# Altium schematic sheet Final out 2.SchDoc("Final out 2")
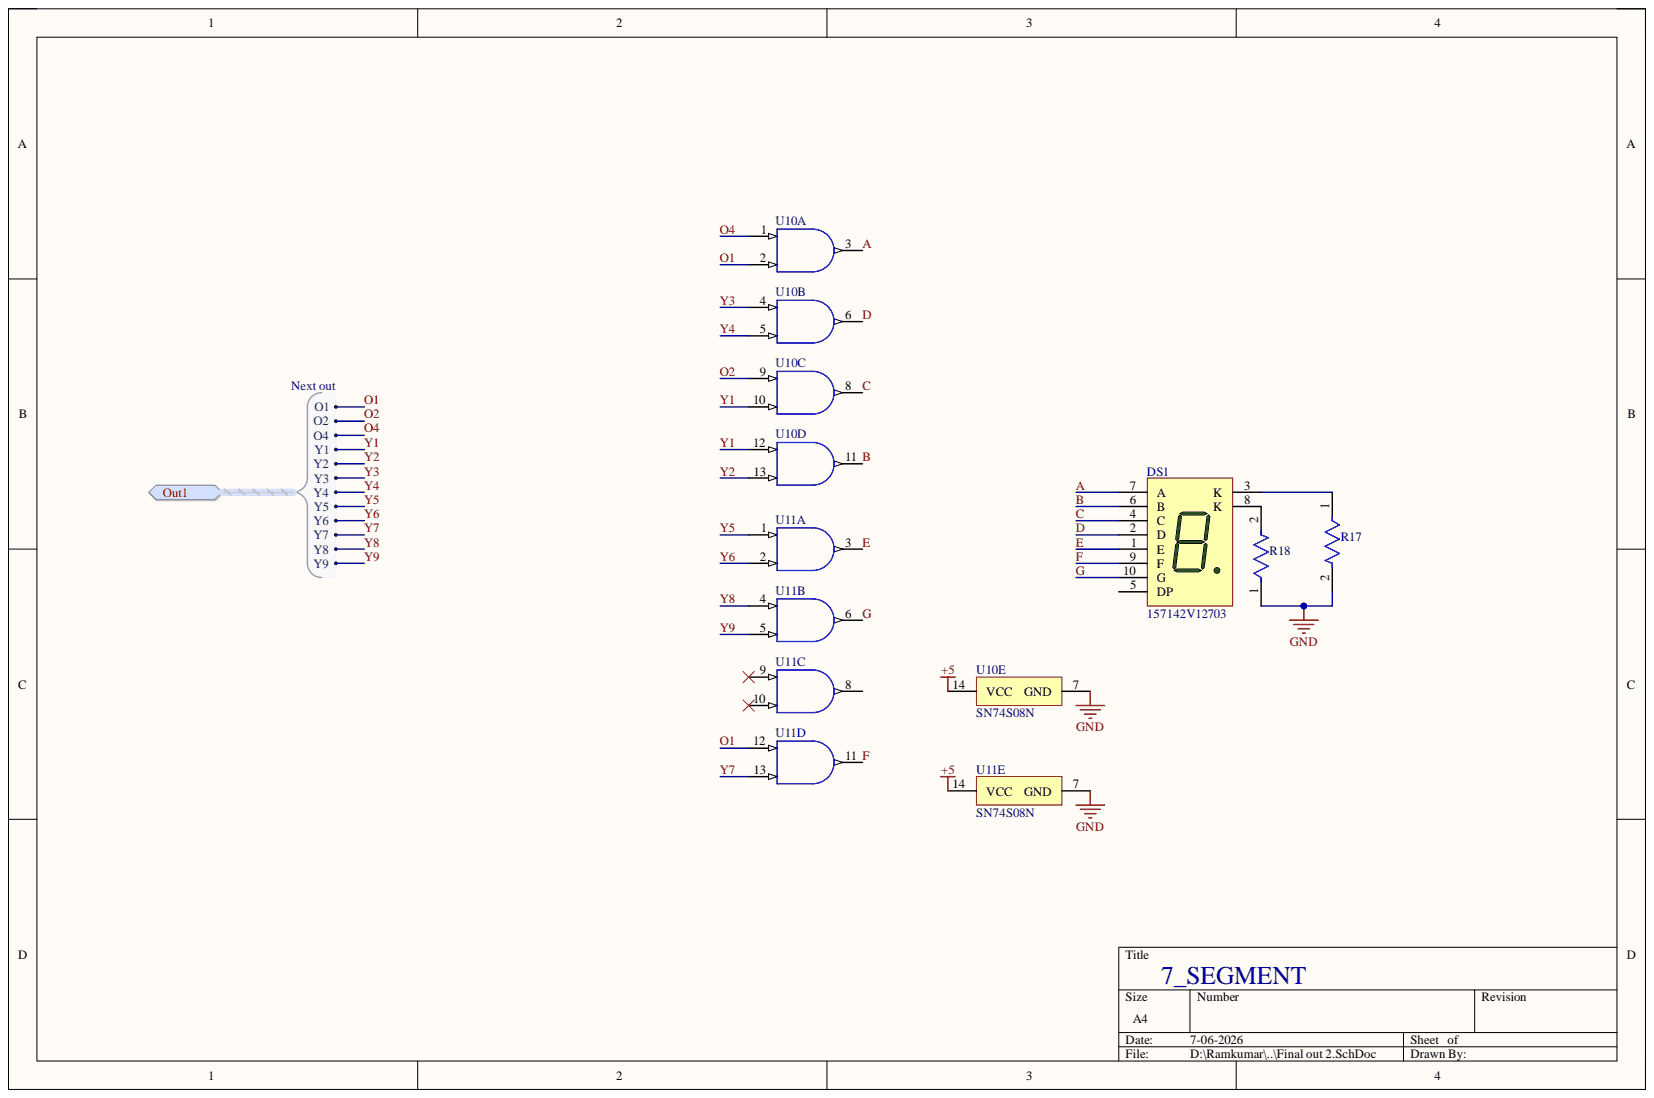
[diagram p0: Final out 2.SchDoc]

## Parts
DS1  157142V12703  [WL-T7DS_L19W12.6H7.9]
R17  MRS25000C1001FCT00  [FP-MRS25-MFG]  (value not drawn)
R18  MRS25000C1001FCT00  [FP-MRS25-MFG]  (value not drawn)
U10  SN74S08N  [N0014A]  (parts A,B,C,D,E)
U11  SN74S08N  [N0014A]  (parts A,B,C,D,E)

## Ports
Out1, Out1.O1, Out1.O2, Out1.O4, Out1.Y1, Out1.Y2, Out1.Y3, Out1.Y4, Out1.Y5, Out1.Y6, Out1.Y7, Out1.Y8, Out1.Y9

## Nets
+5: U10.14(VCC) U11.14(VCC)
GND: R17.2 R18.1 U10.7(GND) U11.7(GND)
A: DS1.7(A) U10.3
B: DS1.6(B) U10.11
C: DS1.4(C) U10.8
D: DS1.2(D) U10.6
E: DS1.1(E) U11.3
F: DS1.9(F) U11.11
G: DS1.10(G) U11.6
O1: U10.2 U11.12 ; port Out1
O2: U10.9 ; port Out1
O4: U10.1 ; port Out1
Y1: U10.10 U10.12 ; port Out1
Y2: U10.13 ; port Out1
Y3: U10.4 ; port Out1
Y4: U10.5 ; port Out1
Y5: U11.1 ; port Out1
Y6: U11.2 ; port Out1
Y7: U11.13 ; port Out1
Y8: U11.4 ; port Out1
Y9: U11.5 ; port Out1
NetDS1_3: DS1.3(K) R17.1
NetDS1_8: DS1.8(K) R18.2

Not connected: DS1 5(DP); U11 8 9 10

## Library data of the parts
DS1 = CMP-03913-119894004-1: 157142V12703 7-Seg Display, Comm Cathode, Grn, 46Mcd Rohs Compliant: Yes; Dominant Wavelength: 572nm; Forward Current: 100mA; Forward Voltage: 2.2V; Luminous Intensity: 25mcd; Max Reverse Voltage (DC): 5V; Component Kind: Standard; Height: 8mm; Length: 19mm; Library Name: Altium Content Vault; Max Operating Temperature: 85°C; Min Operating Temperature: -40°C; NexarPartId: 119894004; RoHS: Compliant; Width: 12.6mm
R17 R18 = CMP-02408-000051-1: RES 1K OHM 0.6W 1% AXIAL; Lead Diameter: 0.6mm; Max Power Dissipation: 600mW; Resistance: 1kR; Temperature Coefficient: 50ppm/°C; Voltage Rating: 350V; Case/Package: Axial; Component Kind: Standard; Construction: Cylindrical; Contact Plating: Tin; Diameter: 2.5mm; Lead Free: Lead Free; Length: 6.5mm; Library Name: Altium Content Vault; Max Operating Temperature: 155°C; Military Standard: Not; Min Operating Temperature: -55°C; Mount: Through Hole; Number of Pins: 2; Number of Terminations: 2; Package Quantity: 5000; Packaging: Tape and Reel; Power Rating: 600mW; Radiation Hardening: No; Resistance Tolerance: 1%; Resistor Type: Metal Film; RoHS Compliant: Yes; Series: MRS25; Technology: THIN FILM; Termination: Axial; Tolerance: 1%; Voltage Rating (DC): 350V; Weight: 0.00776oz; Width: 2.5mm
U10 U11 = CMP-0777-00282-3: Quad 2-input positive-AND gates, N0014A, TUBE; Manufacturer: Texas Instruments; Bits(#): 4; F @ Nom Voltage(Max)(Mhz): 50; ICC @ Nom Voltage(Max)(mA): 0.032; Input Type: TTL; Output Drive (IOL/IOH)(Max)(mA): -24/24; Rating: Catalog; Schmitt Trigger: No; Technology Family: S; tpd @ Nom Voltage(Max)(ns): 7.5; VCC(Max)(V): 5.25; VCC(Min)(V): 4.75; Voltage(Nom)(V): 5; Component Kind: Standard; DatasheetVersion: SDLS033; Generic Part Number: SN74S08; Library Name: Altium Content Vault; Operating Temperature Range(C): 0 to 70; Output Type: TTL; PackageReference: N0014A
